# Altium schematic sheet Master.SchDoc
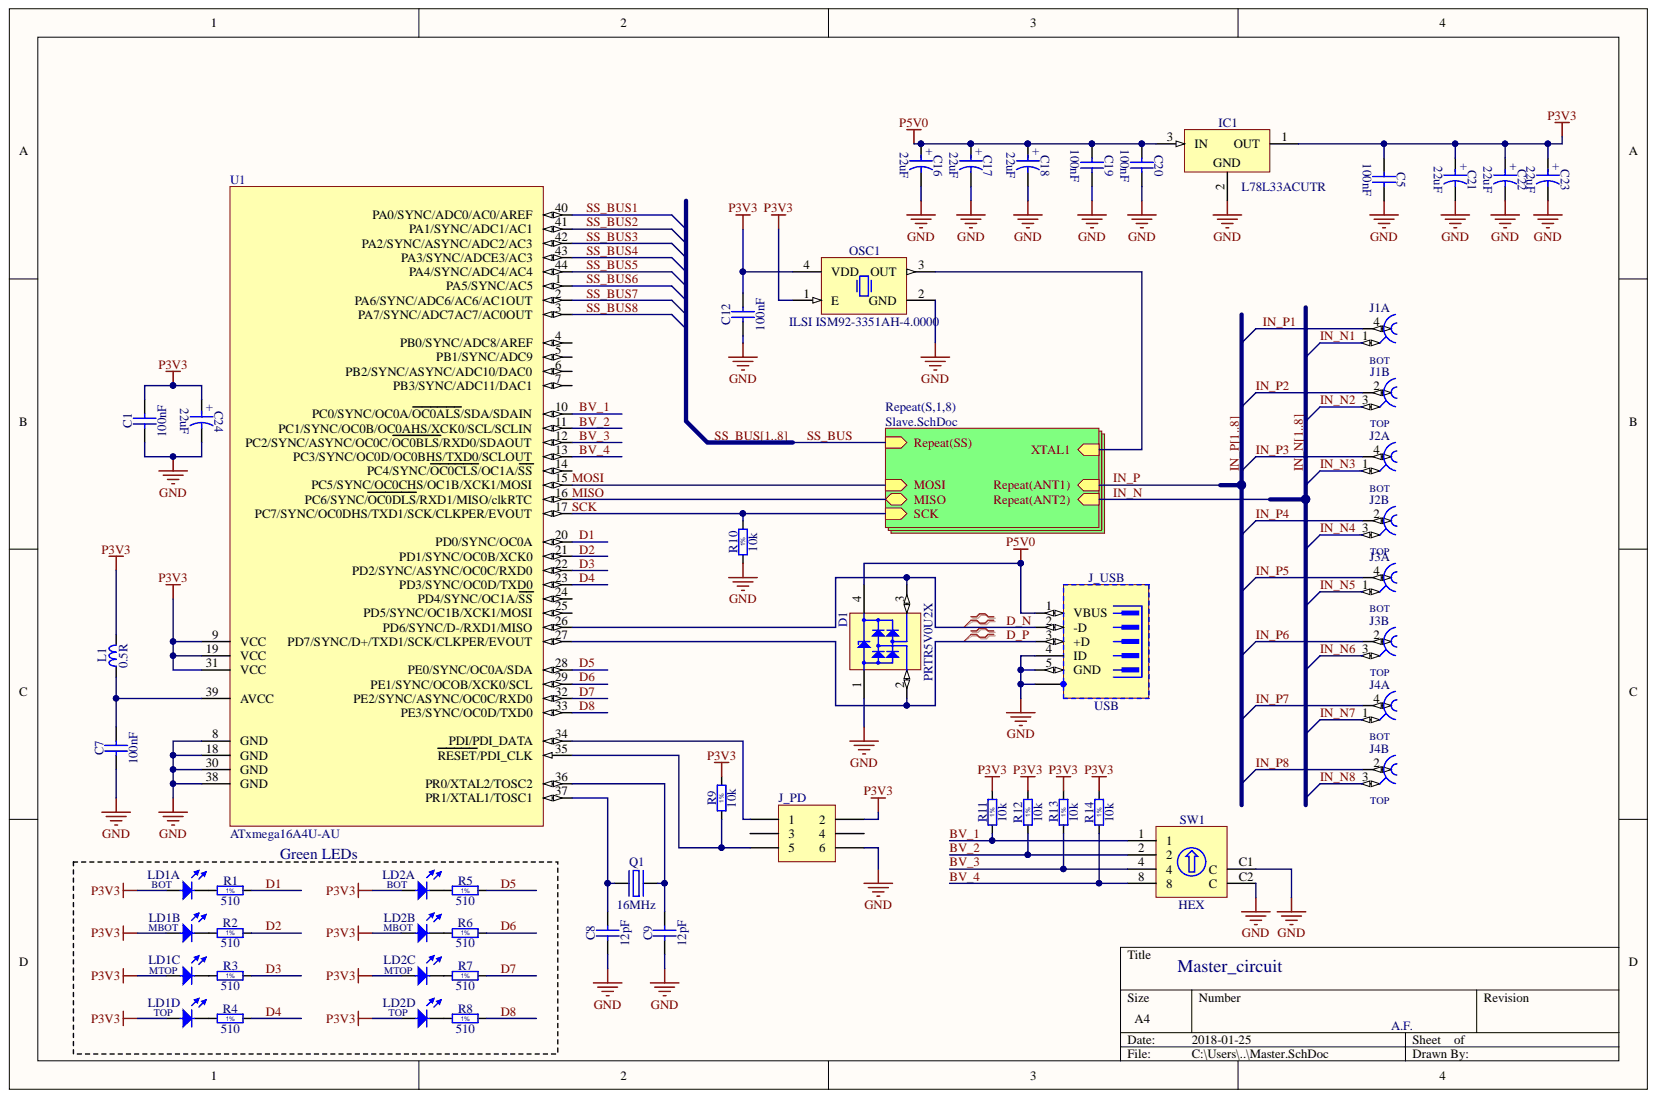
[diagram p0: Master.SchDoc]

## Parts
C1  100nF  [CAPC1608X87N]
C5  100nF  [CAPC1608X87N]
C7  100nF  [CAPC1608X87N]
C8  12pF  [CAPC1608X87N]
C9  12pF  [CAPC1608X87N]
C12  100nF  [CAPC1608X87N]
C16  22uF  [CAPAE430X540N]
C17  22uF  [CAPAE430X540N]
C18  22uF  [CAPAE430X540N]
C19  100nF  [CAPC1608X87N]
C20  100nF  [CAPC1608X87N]
C21  22uF  [CAPAE430X540N]
C22  22uF  [CAPAE430X540N]
C23  22uF  [CAPAE430X540N]
C24  22uF  [CAPAE430X540N]
D1  PRTR5V0U2X  [SOT190P230X110-4N]
IC1  L78L33ACUTR  [SOT89]
J1  Family  [RENHOTEC_RHT-610-0049]  (value not drawn)  (parts A,B)
J2  Family  [RENHOTEC_RHT-610-0049]  (value not drawn)  (parts A,B)
J3  Family  [RENHOTEC_RHT-610-0049]  (value not drawn)  (parts A,B)
J4  Family  [RENHOTEC_RHT-610-0049]  (value not drawn)  (parts A,B)
J_PD  (no value)  [HDR2X3]
J_USB  USB  [WURTH_651005136521]
L1  0.5R  [0603]
LD1  Green  [LED_BIVAR_H485CGDL]  (value not drawn)  (parts A,B,C,D)
LD2  Green  [LED_BIVAR_H485CGDL]  (value not drawn)  (parts A,B,C,D)
OSC1  ILSI ISM92-3351AH-4.0000  [OSCSC250P320X500X130-4N]
Q1  16MHz  [XTAL1160X490X430]
R1  510  [RESC1608X55N]
R2  510  [RESC1608X55N]
R3  510  [RESC1608X55N]
R4  510  [RESC1608X55N]
R5  510  [RESC1608X55N]
R6  510  [RESC1608X55N]
R7  510  [RESC1608X55N]
R8  510  [RESC1608X55N]
R9  10k  [RESC1608X55N]
R10  10k  [RESC1608X55N]
R11  10k  [RESC1608X55N]
R12  10k  [RESC1608X55N]
R13  10k  [RESC1608X55N]
R14  10k  [RESC1608X55N]
SW1  HEX  [SW_OMRON_A6RV-162RF]
U1  ATxmega16A4U-AU  [44A_N]

## Nets
GND: C1.1 C5.2 C7.1 C8.1 C9.1 C12.1 C16.2 C17.2 C18.2 C19.2 C20.2 C21.2 C22.2 C23.2 C24.2 D1.1 IC1.2 J_PD.6 J_USB.4(ID) J_USB.5(GND) J_USB.6 OSC1.2(GND) R10.1 SW1.C1(C) SW1.C2(C) U1.8(GND) U1.18(GND) U1.30(GND) U1.38(GND)
P3V3: C1.2 C5.1 C12.2 C21.1 C22.1 C23.1 C24.1 IC1.1 J_PD.2 L1.2 LD1.2 LD1.4 LD1.6 LD1.8 LD2.2 LD2.4 LD2.6 LD2.8 OSC1.1(E) OSC1.4(VDD) R9.2 R11.2 R12.2 R13.2 R14.2 U1.9(VCC) U1.19(VCC) U1.31(VCC)
P5V0: C16.1 C17.1 C18.1 C19.1 C20.1 D1.4 IC1.3 J_USB.1(VBUS)
BV_1: R11.1 SW1.1 U1.10(PC0/SYNC/OC0A/OC0ALS/SDA/SDAIN)
BV_2: R12.1 SW1.2 U1.11(PC1/SYNC/OC0B/OC0AHS/XCK0/SCL/SCLIN)
BV_3: R13.1 SW1.4 U1.12(PC2/SYNC/ASYNC/OC0C/OC0BLS/RXD0/SDAOUT)
BV_4: R14.1 SW1.8 U1.13(PC3/SYNC/OC0D/OC0BHS/TXD0/SCLOUT)
D1: R1.2 U1.20(PD0/SYNC/OC0A)
D2: R2.2 U1.21(PD1/SYNC/OC0B/XCK0)
D3: R3.2 U1.22(PD2/SYNC/ASYNC/OC0C/RXD0)
D4: R4.2 U1.23(PD3/SYNC/OC0D/TXD0)
D5: R5.2 U1.28(PE0/SYNC/OC0A/SDA)
D6: R6.2 U1.29(PE1/SYNC/OCOB/XCK0/SCL)
D7: R7.2 U1.32(PE2/SYNC/ASYNC/OC0C/RXD0)
D8: R8.2 U1.33(PE3/SYNC/OC0D/TXD0)
D_N: D1.3 J_USB.2(-D) U1.26(PD6/SYNC/D-/RXD1/MISO)
D_P: D1.2 J_USB.3(+D) U1.27(PD7/SYNC/D+/TXD1/SCK/CLKPER/EVOUT)
IN_N1: J1.1  [also labelled IN_N]
IN_N2: J1.3  [also labelled IN_N]
IN_N3: J2.1  [also labelled IN_N]
IN_N4: J2.3  [also labelled IN_N]
IN_N5: J3.1  [also labelled IN_N]
IN_N6: J3.3  [also labelled IN_N]
IN_N7: J4.1  [also labelled IN_N]
IN_N8: J4.3  [also labelled IN_N]
IN_P1: J1.4  [also labelled IN_P]
IN_P2: J1.2  [also labelled IN_P]
IN_P3: J2.4  [also labelled IN_P]
IN_P4: J2.2  [also labelled IN_P]
IN_P5: J3.4  [also labelled IN_P]
IN_P6: J3.2  [also labelled IN_P]
IN_P7: J4.4  [also labelled IN_P]
IN_P8: J4.2  [also labelled IN_P]
MISO: U1.16(PC6/SYNC/OC0DLS/RXD1/MISO/clkRTC)
MOSI: U1.15(PC5/SYNC/OC0CHS/OC1B/XCK1/MOSI)
SCK: R10.2 U1.17(PC7/SYNC/OC0DHS/TXD1/SCK/CLKPER/EVOUT)
SS_BUS1: U1.40(PA0/SYNC/ADC0/AC0/AREF)  [also labelled SS_BUS]
SS_BUS2: U1.41(PA1/SYNC/ADC1/AC1)  [also labelled SS_BUS]
SS_BUS3: U1.42(PA2/SYNC/ASYNC/ADC2/AC3)  [also labelled SS_BUS]
SS_BUS4: U1.43(PA3/SYNC/ADCE3/AC3)  [also labelled SS_BUS]
SS_BUS5: U1.44(PA4/SYNC/ADC4/AC4)  [also labelled SS_BUS]
SS_BUS6: U1.1(PA5/SYNC/AC5)  [also labelled SS_BUS]
SS_BUS7: U1.2(PA6/SYNC/ADC6/AC6/AC1OUT)  [also labelled SS_BUS]
SS_BUS8: U1.3(PA7/SYNC/ADC7AC7/AC0OUT)  [also labelled SS_BUS]
NetC7_2: C7.2 L1.1 U1.39(AVCC)
NetC8_2: C8.2 Q1.1 U1.37(PR1/XTAL1/TOSC1)
NetC9_2: C9.2 Q1.2 U1.36(PR0/XTAL2/TOSC2)
NetJ_PD_1: J_PD.1 U1.34(PDI/PDI_DATA)
NetJ_PD_5: J_PD.5 R9.1 U1.35(RESET/PDI_CLK)
NetLD1_1: LD1.1 R1.1
NetLD1_3: LD1.3 R2.1
NetLD1_5: LD1.5 R3.1
NetLD1_7: LD1.7 R4.1
NetLD2_1: LD2.1 R5.1
NetLD2_3: LD2.3 R6.1
NetLD2_5: LD2.5 R7.1
NetLD2_7: LD2.7 R8.1
~{}:

Not connected: J_PD 3 4; OSC1 3(OUT); U1 4(PB0/SYNC/ADC8/AREF) 5(PB1/SYNC/ADC9) 6(PB2/SYNC/ASYNC/ADC10/DAC0) 7(PB3/SYNC/ADC11/DAC1) 14(PC4/SYNC/OC0CLS/OC1A/SS) 24(PD4/SYNC/OC1A/SS) 25(PD5/SYNC/OC1B/XCK1/MOSI)

## Library data of the parts
C1 C5 C7 C12 C19 C20 = CC0603_100NF_50V_10%_X5R: Non-polarised capacitor; Manufacturer: GENERIC; Manufacturer1 Part Number: C1608X5R1H104K080AA; Part Description: SMD Multilayer Chip Ceramic Capacitor; Database Table Name: Capacitors SMD; TC: X5R; Tolerance: ±10%; Voltage: 50V; Case: 0603; Component Kind: Standard; Component Type: Standard; CreateDate: 08.01.2016; HelpURL: \\cern.ch\dfs\Applications\Altium\Datasheets\CC0603_X5R_TDK_C.pdf; LatestRevisionDate: 08.01.2016; Library Name: Capacitors.DbLib; Manufacturer1 ComponentHeight: 0.9mm; Manufacturer1 Example: TDK; Manufacturer Part Number: CC0603_100NF_50V_10%_X5R; Mounted: Yes; Part Number: CC0603_100NF_50V_10%_X5R; Pin Count: 2; Sense: No; SMD: Yes; Socket: No; Status: None; Value: 100nF; Author: CERN DEM MR
C8 C9 = CC0603_12PF_50V_5%_NP0: Non-polarised capacitor; Manufacturer: GENERIC; Manufacturer1 Part Number: 223886715129; Part Description: SMD Multilayer Chip Ceramic Capacitor; Database Table Name: Capacitors SMD; TC: C0G/NP0; Tolerance: ±5%; Voltage: 50V; Case: 0603; Component Kind: Standard; Component Type: Standard; CreateDate: 03.12.2007; HelpURL: \\cern.ch\dfs\Applications\Altium\Datasheets\CC0603_NP0_PHYCOMP.pdf; LatestRevisionDate: 03.12.2007; Library Name: Capacitors.DbLib; Manufacturer1 ComponentHeight: 0.87mm; Manufacturer1 Example: PHYCOMP; Manufacturer Part Number: CC0603_12PF_50V_5%_NP0; Mounted: Yes; Part Number: CC0603_12PF_50V_5%_NP0; Pin Count: 2; Sense: No; SMD: Yes; Socket: No; Status: Preferred; Value: 12pF; Author: CERN DEM JLC
C16-C18 C21-C24 = CAE430-540_22UF_10V_20%: Polarised capacitor; Manufacturer: PANASONIC; Manufacturer Part Number: EEEFK1A220AR; Part Description: Aluminum Electrolytic SMT Capacitor; Database Table Name: Capacitors SMD; Tolerance: ±20%; Voltage: 10V; Case: B; ComponentHeight: 5.8mm; Component Kind: Standard; Component Type: Standard; CreateDate: 2007-12-03; HelpURL: \\cern.ch\dfs\Applications\Altium\Datasheets\CAE_PANASONIC_FK.pdf; LatestRevisionDate: 2014-11-05; Library Name: Capacitors.DbLib; Mounted: Yes; Part Number: CAE430-540_22UF_10V_20%; Pin Count: 2; Sense: No; SMD: Yes; Socket: No; Status: Not Recommended; Value: 22uF; Author: CERN DEM JLC
D1 = PRTR5V0U2X: Ultra Low Capacitance Double Rail-to-Rail ESD Protection Diode; Manufacturer: NXP SEMICONDUCTORS; Part Description: Ultra Low Capacitance (1pF) Double Rail-to-Rail ESD Protection Diode (8kV); Bonding: No; Database Table Name: Diodes; PressFit: No; Voltage: 5.5V; Case: SOT143B; ComponentHeight: 1.1mm; Component Kind: Standard; Component Type: Standard; CreateDate: 2011-03-22; Device: PRTR5V0U2X; HelpURL: \\cern.ch\dfs\Applications\Altium\Datasheets\PRTR5V0U2X.pdf; LatestRevisionDate: 2011-03-22; Library Name: ICs And Semiconductors.DbLib; Manufacturer Part Number: PRTR5V0U2X; Mounted: Yes; PackageDescription: SOT143B, SOT, 1.90mm Pitch; 4 Pin, 1.30mm W X 2.90mm L X 1.10mm H body, IPC Medium Density; Part Number: PRTR5V0U2X; Pin Count: 4; Sense: No; SMD: Yes; Socket: No; Author: CERN DEM JLC
IC1 = L78L33ACUTR: 3-Terminal Regulator 1=OUT 2=GND 3=IN; Manufacturer: ST MICROELECTRONICS; Part Description: 33V 100mA Positive Voltage Regulator; Bonding: No; Database Table Name: Regulators; PressFit: No; Case: SOT89; ComponentHeight: 1.6mm; Component Kind: Standard; Component Type: Standard; CreateDate: 2014-05-12; Device: L78L33ACUTR; HelpURL: \\cern.ch\dfs\Applications\Altium\Datasheets\L78L33ACUTR.pdf; LatestRevisionDate: 2014-05-12; Library Name: ICs And Semiconductors.DbLib; Manufacturer Part Number: L78L33ACUTR; Mounted: Yes; PackageDescription: SOT89-3, 3-Leads, Body 2.44x4.5mm; Part Number: L78L33ACUTR; Pin Count: 4; Sense: No; SMD: Yes; Socket: No; Author: CERN DEM JLC
J1-J4 = (no symbol name): Dual Right Angle 50 Ohm BNC Jack, White Valox Case; Manufacturer: RENHOTEC; Manufacturer Part Number: RHT-610-0049; PressFit: No; ComponentHeight: 29.3mm; Component Kind: Standard; Component Type: Standard; CreateDate: 09.04.2013; Family: BNC; HelpURL: \\cern.ch\dfs\Applications\Altium\Datasheets\BOMAR_364A2595.pdf; LatestRevisionDate: 09.04.2013; Library Name: LIBRARY_SCH.SCHLIB; Mounted: Yes; Part Description: Dual Right Angle 50 Ohm BNC Jack, White Valox Case; Part Number: RENHOTEC_RHT-610-0049; Pin Count: 6; Sense: No; SMD: No; Socket: No; Status: None; Author: GK
J_PD = Header 3X2: Header, 3-Pin, Dual row; Component Kind: Standard; LatestRevisionDate: 17-Jul-2002; LatestRevisionNote: Re-released for DXP Platform.; Library Name: Miscellaneous Connectors.IntLib; Signal Integrity: Connector; Publisher: Altium Limited
J_USB = WURTH_651005136521: 5 Pin + 1 Shield USB Receptacle; Manufacturer: WURTH ELEKTRONIK; Part Description: Mini USB Type B  Receptacle, Horizontal, 5 Contacts; Database Table Name: Miscellaneous; PressFit: No; ComponentHeight: 3.9mm; Component Kind: Standard; Component Type: Standard; CreateDate: 2011-03-07; Family: USB; HelpURL: \\cern.ch\dfs\Applications\Altium\Datasheets\WURTH_651005136521.pdf; LatestRevisionDate: 2011-03-07; Library Name: Connectors.DbLib; Manufacturer Part Number: 651005136521; Mounted: Yes; Part Number: WURTH_651005136521; Pin Count: 6; Sense: No; SMD: No; Socket: No; Status: None; Author: CERN DEM JLC
L1 = IND_1UH_20%_BOURNS_SRP4020TA: Ferrite Bead; Manufacturer: BOURNS; Part Description: Shielded Power Inductor; Impedence at 100MHZ: 1500R; Power: 0.5A; Producer: Murata; Component Kind: Standard; HelpURL: https://www.tme.eu/html/EN/murata-smd-beads/ramka_6596_EN_pelny.html; Mounted: Yes; Pin Count: 2; Resistance: 0.5R; SMD: Yes; Socket: No; Status: None; Value: 0.5R; Author: CERN DEM BC
LD1 LD2 = (no symbol name): LED x4 Green 1C2A-BOT_3C4A_5C6A_7C8A-TOP; Manufacturer: BIVAR; Manufacturer Part Number: H485CGDL; Part Description: Quad-Level 2mm Green LED, PCB Edge Mounting; Bonding: No; PressFit: No; Color: Green; ComponentHeight: 11.3mm; Component Kind: Standard; Component Type: Standard; CreateDate: 2012-06-04; HelpURL: \\cern.ch\dfs\Applications\Altium\Datasheets\LED_BIVAR_H485CGDL.pdf; LatestRevisionDate: 2012-06-04; Library Name: RFID_system.SCHLIB; Mounted: Yes; PackageDescription: Quad-Level 2mm Green, PCB Edge Mounting  BIVAR H485CHDL; Part Number: LED_BIVAR_H485CGDL; Pin Count: 8; Sense: No; SMD: No; Socket: No; Author: CERN DEM JLC
OSC1 = Oscillator 1E 2GND 3OUT 4VDD: Oscillator 4 pins 3.3V 4MHz; Component Kind: Standard; Library Name: Crystals & Oscillators.SchLib; URL: https://www.tme.eu/pl/Document/04fa0ef07f38450711886bdf482cf79c/ISM92_Series.pdf
Q1 = XTAL_16MHZ_IQD_LFXTAL003237: Quartz Crystal; Manufacturer: IQD FREQUENCY PRODUCTS; Part Description: Tolerance: ± 30ppm, Stability: ± 50ppm, -10°C to +60°C, 16pF, Surface Mount Quartz Crystal, HC49/4HSMX Series; Bonding: No; Database Table Name: Crystals & Oscillators; PressFit: No; ComponentHeight: 4.3mm; Component Kind: Standard; Component Type: Standard; CreateDate: 2016-03-23; HelpURL: \\cern.ch\dfs\Applications\Altium\Datasheets\XTAL_IQD_LFXTAL003237.pdf; LatestRevisionDate: 2016-11-07; Library Name: ICs And Semiconductors.DbLib; Manufacturer Part Number: LF XTAL003237; Mounted: Yes; PackageDescription: HC49/4H SMX Case, SMD Cristal, Body 11.6x4.9mm; Part Number: XTAL_16MHZ_IQD_LFXTAL003237; Pin Count: 2; Sense: No; SMD: Yes; Socket: No; Value: 16MHz; Author: CERN DEM MR
R1-R8 = R0603_510R_1%_0.1W_100PPM: Resistor - 1%; Manufacturer: GENERIC; Manufacturer1 Part Number: NRC06F5100TRF; Part Description: General Purpose Thick Film Chip Resistor; Database Table Name: Resistors SMD; Power: 0.1W; PressFit: No; TC: ±100ppm/°C; Case: 0603; Component Kind: Standard; Component Type: Standard; CreateDate: 2007-12-03; HelpURL: \\cern.ch\dfs\Applications\Altium\Datasheets\R0603_NIC_NRC.pdf; LatestRevisionDate: 2008-03-13; Library Name: Resistors.DbLib; Manufacturer1 ComponentHeight: 0.55mm; Manufacturer1 Example: NIC COMPONENT; Manufacturer Part Number: R0603_510R_1%_0.1W_100PPM; Mounted: Yes; Part Number: R0603_510R_1%_0.1W_100PPM; Pin Count: 2; Sense: No; SMD: Yes; Socket: No; Status: Preferred; Tolerance: ±1%; Value: 510; Author: CERN DEM JLC
R9-R14 = R0603_10K_1%_0.1W_100PPM: Resistor - 1%; Manufacturer: GENERIC; Manufacturer1 Part Number: NRC06F1002TRF; Part Description: General Purpose Thick Film Chip Resistor; Database Table Name: Resistors SMD; Power: 0.1W; PressFit: No; TC: ±100ppm/°C; Case: 0603; Component Kind: Standard; Component Type: Standard; CreateDate: 03.12.2007; HelpURL: \\cern.ch\dfs\Applications\Altium\Datasheets\R0603_NIC_NRC.pdf; LatestRevisionDate: 13.03.2008; Library Name: Resistors.DbLib; Manufacturer1 ComponentHeight: 0.55mm; Manufacturer1 Example: NIC COMPONENT; Manufacturer Part Number: R0603_10K_1%_0.1W_100PPM; Mounted: Yes; Part Number: R0603_10K_1%_0.1W_100PPM; Pin Count: 2; Sense: No; SMD: Yes; Socket: No; Status: Preferred; Tolerance: ±1%; Value: 10k; Author: CERN DEM JLC
SW1 = SW_OMRON_A6RV-162RF: Rotary Code Switch 1 2 4 8 C1 C2; Manufacturer: OMRON; Manufacturer Part Number: A6RV-162RF or ERD716RR; Part Description: Side Actuated, Hexadecimal Rotary Code Switch; Part Number: SW_OMRON_A6RV-162RF or ERD716RR; Database Table Name: Switches; PressFit: No; ComponentHeight: 9.9mm; Component Kind: Standard; Component Type: Standard; CreateDate: 2008-11-27; Family: HEX; HelpURL: \\cern.ch\dfs\Applications\Altium\Datasheets\SW_OMRON_A6RV.pdf; LatestRevisionDate: 2011-01-19; Library Name: Eletro-mechanical.DbLib; Mounted: Yes; PackageDescription: Body 9.8x6.64mm, Side Actuated, Rotary Code Switch, OMRON A6RV Series; Pin Count: 6; Sense: No; SMD: No; Socket: No; Status: None; Author: CERN DEM JLC
U1 = ATxmega16A4-AU: 8/16-bit AVR XMEGA A4 Microcontroller, 1.6-3.6V, 16KB + 4KB Flash, 1KB EEPROM, 2KB SRAM, 44-pin TQFP, Industrial Grade (-40°C to 85°C), Pb-Free; Component Kind: Standard; ComponentLink1Description: Manufacturer Link; ComponentLink1URL: http://www.atmel.com/products/avr/default.asp?; ComponentLink2Description: ATxmega16A4/32A4/64A4/128A4 Datasheet; ComponentLink2URL: http://www.atmel.com/dyn/resources/prod_documents/doc8069.pdf; ComponentLink3Description: Atmel.com Home; ComponentLink3URL: http://www.atmel.com; DatasheetVersion: revN, Feb-2010; PackageDescription: 44-Pin Plastic Thin Profile Quad Flat Pack, 10 x 10 x 1.0 mm Body, 0.8 mm Pitch (TQFP); PackageReference: 44A; PackageVersion: rev.B, Oct-2001; Published: 1-Apr-2010; Supplier 1: Digi-Key; Supplier 2: Farnell; Supplier 3: Mouser; Supplier Part Number 1: ATXMEGA16A4-AU-ND; Supplier Part Number 2: 1748552; Supplier Part Number 3: 556-ATXMEGA16A4-AU; Library Name: RFID_system_atxmegau.SCHLIB; Publisher: Altium Limited
